# Altium schematic sheet canbus.SchDoc
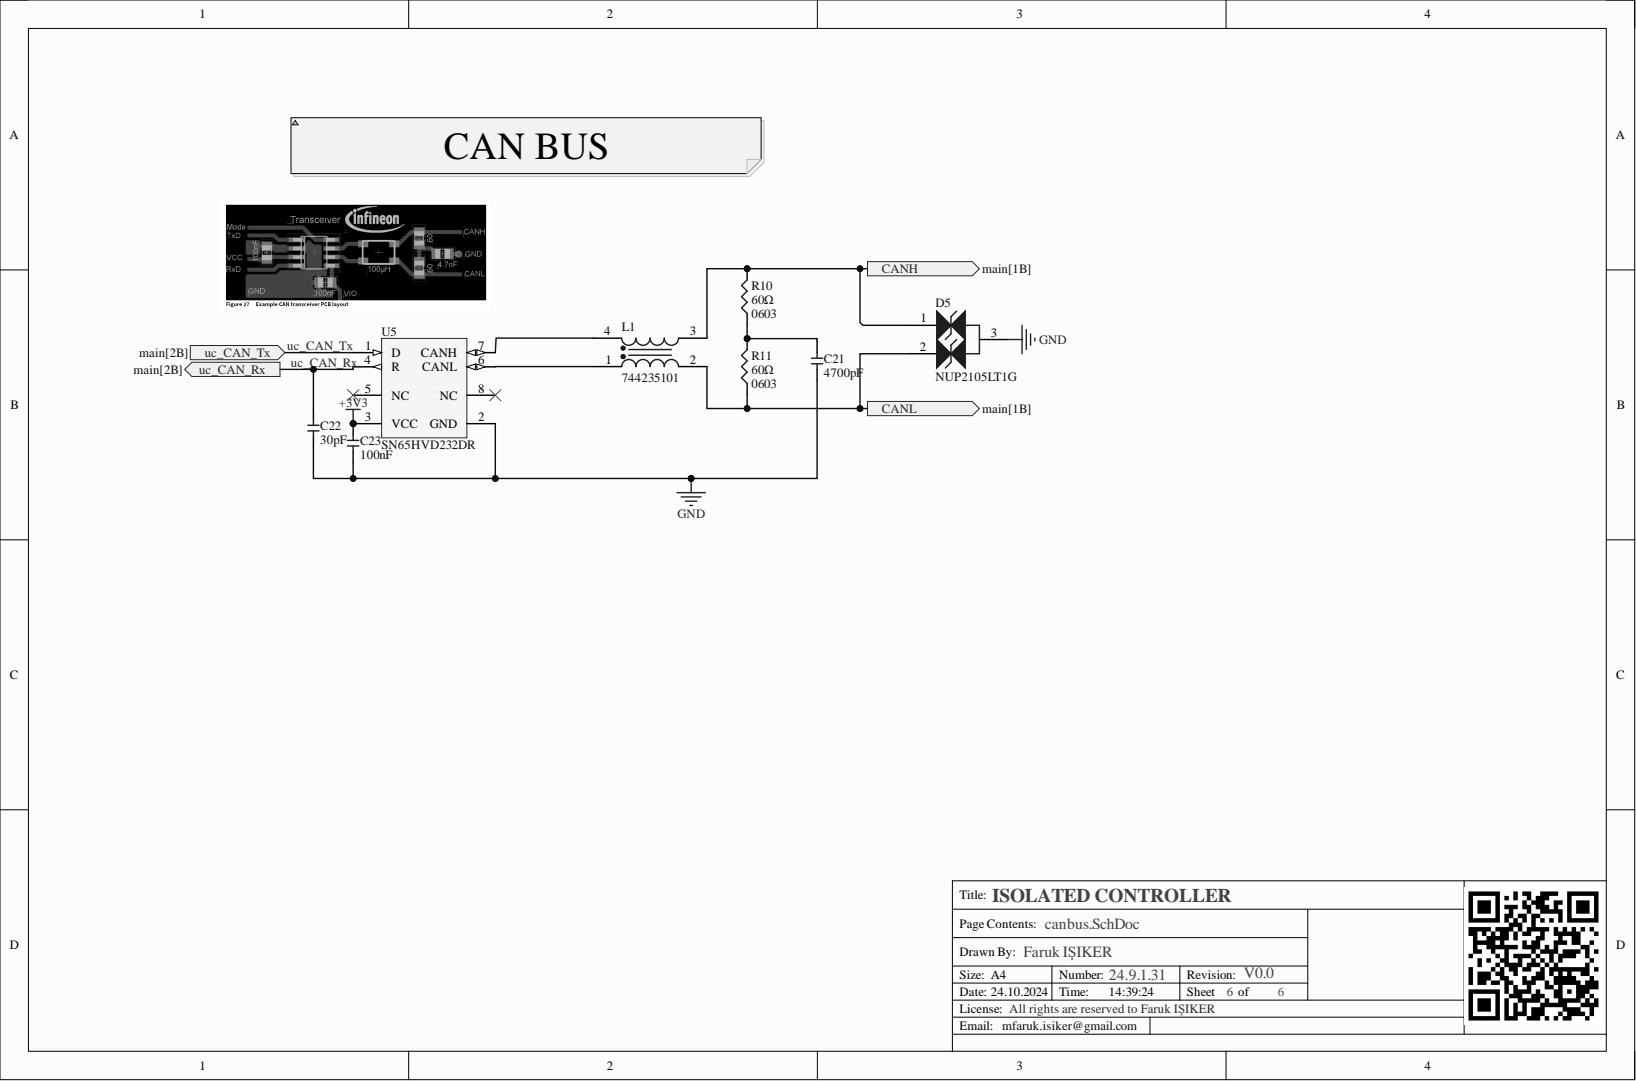
[diagram p0: canbus.SchDoc]

## Parts
C21  value 4700pF  [0603_cap]  (hidden comment: 0603_4700pF)
C22  value 30pF  [0603_cap]  (hidden comment: 0603_30pF)
C23  value 100nF  [0603_cap]  (hidden comment: 0603_100nF)
D5  NUP2105LT1G  [ONSC-SOT-23-3-318-08_V]
L1  744235101  [WE-CNSW_1812]
R10  0603_60R  value 60Ω  Package: 0603  [0603-smd]
R11  0603_60R  value 60Ω  Package: 0603  [0603-smd]
U5  SN65HVD232DR  [SN65HVD232]

## Ports
CANH, CANL, uc_CAN_Rx, uc_CAN_Tx

## Nets
+3V3: C23.2 U5.3(VCC)
GND: C21.1 C22.1 C23.1 D5.3 U5.2(GND)
CANH: D5.1 L1.3 R10.2 ; port CANH
CANL: D5.2 L1.2 R11.1 ; port CANL
uc_CAN_Rx: C22.2 U5.4(R) ; port uc_CAN_Rx
uc_CAN_Tx: U5.1(D) ; port uc_CAN_Tx
NetC21_2: C21.2 R10.1 R11.2
NetL1_1: L1.1 U5.6(CANL)
NetL1_4: L1.4 U5.7(CANH)
NetU5_5: U5.5(NC)
NetU5_8: U5.8(NC)

## Library data of the parts
C21 = 0603_4700pF: Volt: V; Component Kind: Standard; Library Name: generic.SchLib; Package: 0603
C22 = 0603_30pF: Volt: V; Component Kind: Standard; Library Name: generic.SchLib; Package: 0603
C23 = 0603_100nF: Volt: V; Component Kind: Standard; Library Name: generic.SchLib; Package: 0603
D5 = CMP-1063-00164-1: Dual Line CAN Bus Protector, 3-Pin SOT-23, Pb-Free, Tape and Reel; Manufacturer: On Semiconductor; C Max (pF): 30; Direction: Bidirectional; Number of Lines: 2; PPK Max (W): 350; V(BR) Min (V): 26.2; IR Max (uA): 0.1; Component Kind: Standard; ComponentLink1Description: Manufacturer URL; ComponentLink1URL: http://www.onsemi.com/; ComponentLink2Description: Datasheet; ComponentLink2URL: http://www.onsemi.cn/pub_link/Collateral/NUP2105L-D.PDF; ComponentLink3Description: Package Specification; ComponentLink3URL: http://www.onsemi.cn/pub_link/Collateral/318-08.PDF; DatasheetVersion: Rev. 5; Library Name: Altium Content Vault; Mounting Technology: Surface Mount; PackageDescription: 3-Pin Small Outline Transistor, Body 2.92 x 1.3 mm, Pitch 0.95 mm; PackageReference: SOT-23-3-318-08; PackageVersion: Rev. AP, 11/2009; Packing: Tape and Reel; PartNumber: NUP2105LT1G; RoHS: Pb-Free
L1 = CMP-207849-000010-2: CMC 100UH 150MA 2LN 8 KOHM SMD; NexarPartId: 61645269; DC Resistance (DCR): 2Ω; Number of Lines: 2; Test Frequency: 100kHz; Voltage Rating: 60V; Inductance: 100µH; Ambient Temperature Range High: 85°C; Ambient Temperature Range Low: -40°C; Component Kind: Standard; Composition: Wirewound; Current Rating: 150mA; Height: 2.8mm; Length: 4.5mm; Library Name: Altium Content Vault; Max Current Rating: 150mA; Max Operating Temperature: 125°C; Min Operating Temperature: -40°C; Mount: Surface Mount; Number of Pins: 4; Packaging: Tape & Reel (TR); RMS Current (Irms): 150mA; RoHS: Compliant; Series Resistance: 2Ω; Voltage Rating (DC): 60V; Width: 3.2mm
R10 R11 = 0603_60R: Power: W; Component Kind: Standard; Library Name: generic.SchLib
U5 = CMP-0315-00075-2: 3.3 V Can Transceiver, 17 mA, -40 to 85 degC, 8-pin SOIC (D), Green (RoHS & no Sb/Br); Manufacturer: Texas Instruments; Drivers Per Package: 1; Rating: Catalog; Supply Voltage(s)(V): 3.3; Transient Pulse Protection(V): -25 to 25; ICC(Max)(mA): 17; Code_JEDEC: MS-012AA; Component Kind: Standard; ComponentLink1Description: Datasheet; ComponentLink1URL: http://www.ti.com/general/docs/lit/getliterature.tsp?genericPartNumber=SN65HVD232&fileType=pdf; DatasheetVersion: SLOS346K; Eco-Plan: Green (RoHS & no Sb/Br); Library Name: Altium Content Vault; Mounting Technology: Surface Mount; PackageDescription: 8-Pin Plastic Small Outline Integrated Circuit, 4.9 x 3.9 mm, Pitch 1.27 mm Pitch; PackageReference: D0008A; PackageVersion: revM, Jun-2011; PartNumber: SN65HVD232DR; Receivers Per Package: 1; SubFamily: CAN; Isolated: No
